# Altium schematic sheet Power.SchDoc(Power)
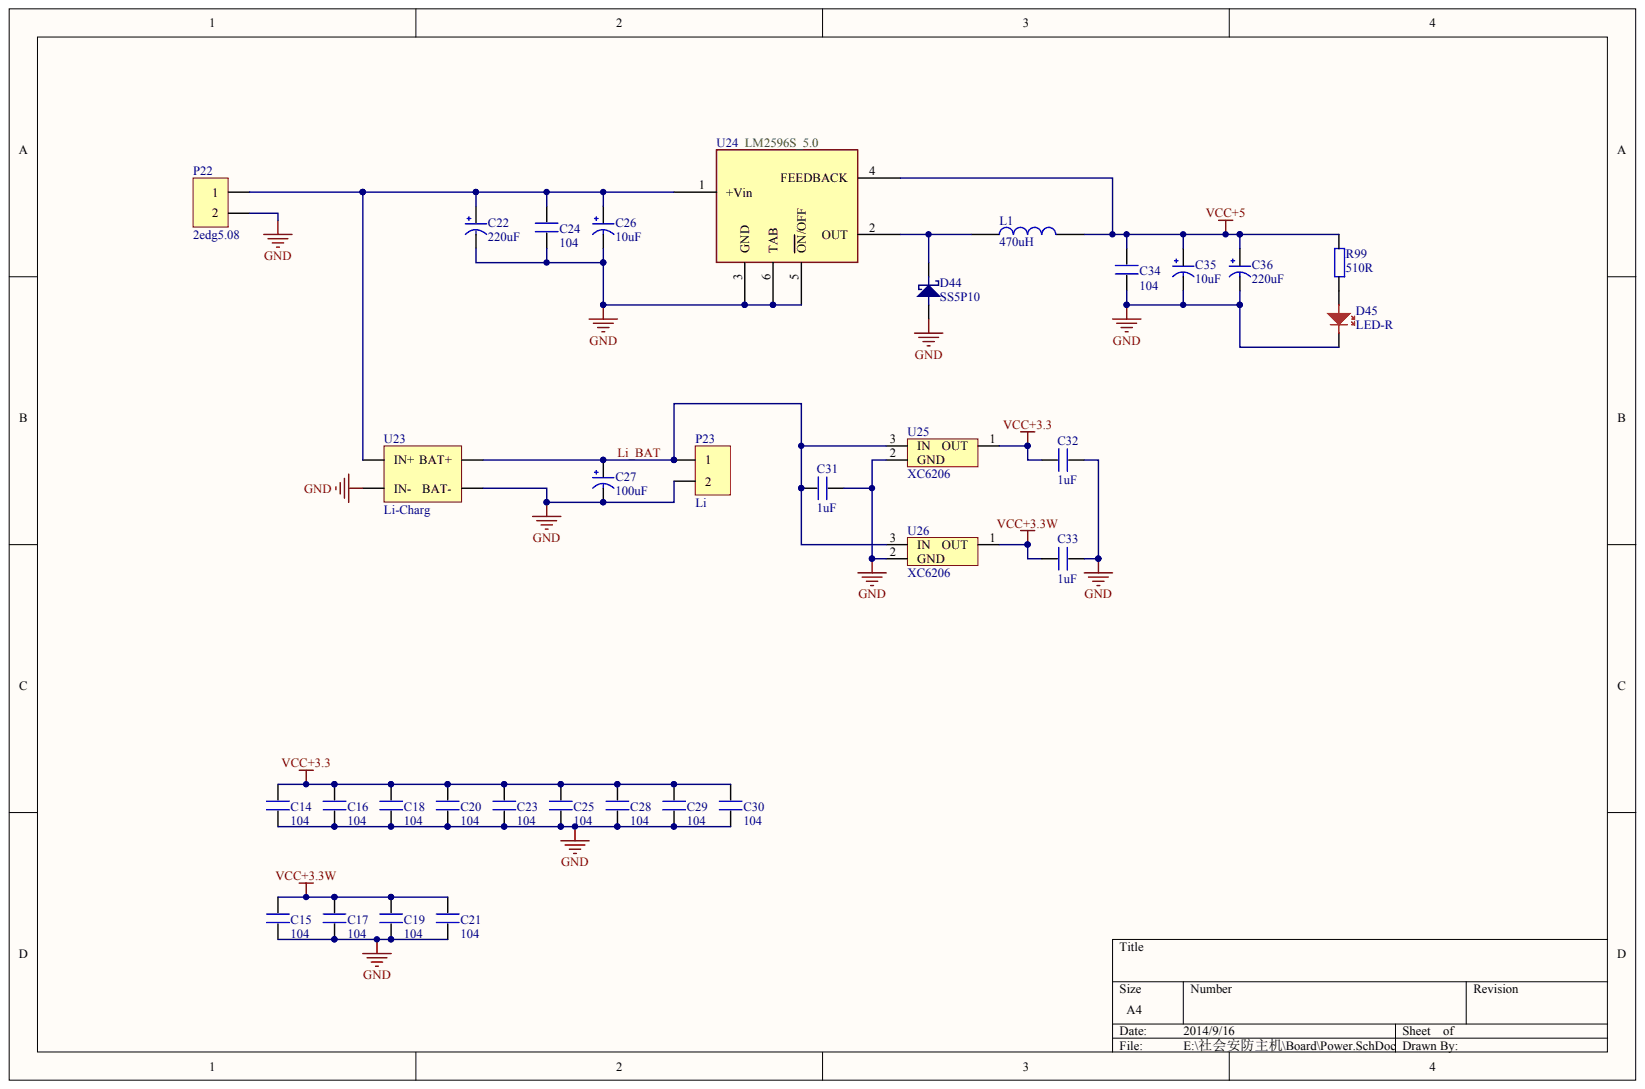
[diagram p0: Power.SchDoc]

## Parts
C14  104
C15  104
C16  104
C17  104
C18  104
C19  104
C20  104
C21  104
C22  220uF
C23  104
C24  104
C25  104
C26  10uF
C27  100uF
C28  104
C29  104
C30  104
C31  1uF
C32  1uF
C33  1uF
C34  104
C35  10uF
C36  220uF
D44  SS5P10
D45  LED-R
L1  470uH
P22  2edg5.08
P23  Li
R99  510R
U23  Li-Charg
U24  LM2596S_5.0
U25  XC6206
U26  XC6206

## Nets
GND: C14.1 C15.1 C16.1 C17.1 C18.1 C19.1 C20.1 C21.1 C22.2 C23.1 C24.1 C25.1 C26.2 C27.2 C28.1 C29.1 C30.1 C31.2 C32.2 C33.2 C34.1 C35.2 C36.2 D44.1 D45.2 P22.2 P23.2 U23.2(IN-) U23.3(BAT-) U24.3(GND) U24.5(ON/OFF) U24.6(TAB) U25.2 U26.2
VCC+3.3: C14.2 C16.2 C18.2 C20.2 C23.2 C25.2 C28.2 C29.2 C30.2 C32.1 U25.1
VCC+3.3W: C15.2 C17.2 C19.2 C21.2 C33.1 U26.1
VCC+5: C34.2 C35.1 C36.1 L1.2 R99.2 U24.4(FEEDBACK)
LI_BAT: C27.1 C31.1 P23.1 U23.4(BAT+) U25.3 U26.3
NetC22_1: C22.1 C24.2 C26.1 P22.1 U23.1(IN+) U24.1(+Vin)
NetD44_2: D44.2 L1.1 U24.2(OUT)
NetD45_1: D45.1 R99.1
